# Altium schematic sheet Sheet1.SchDoc(Sheet1)
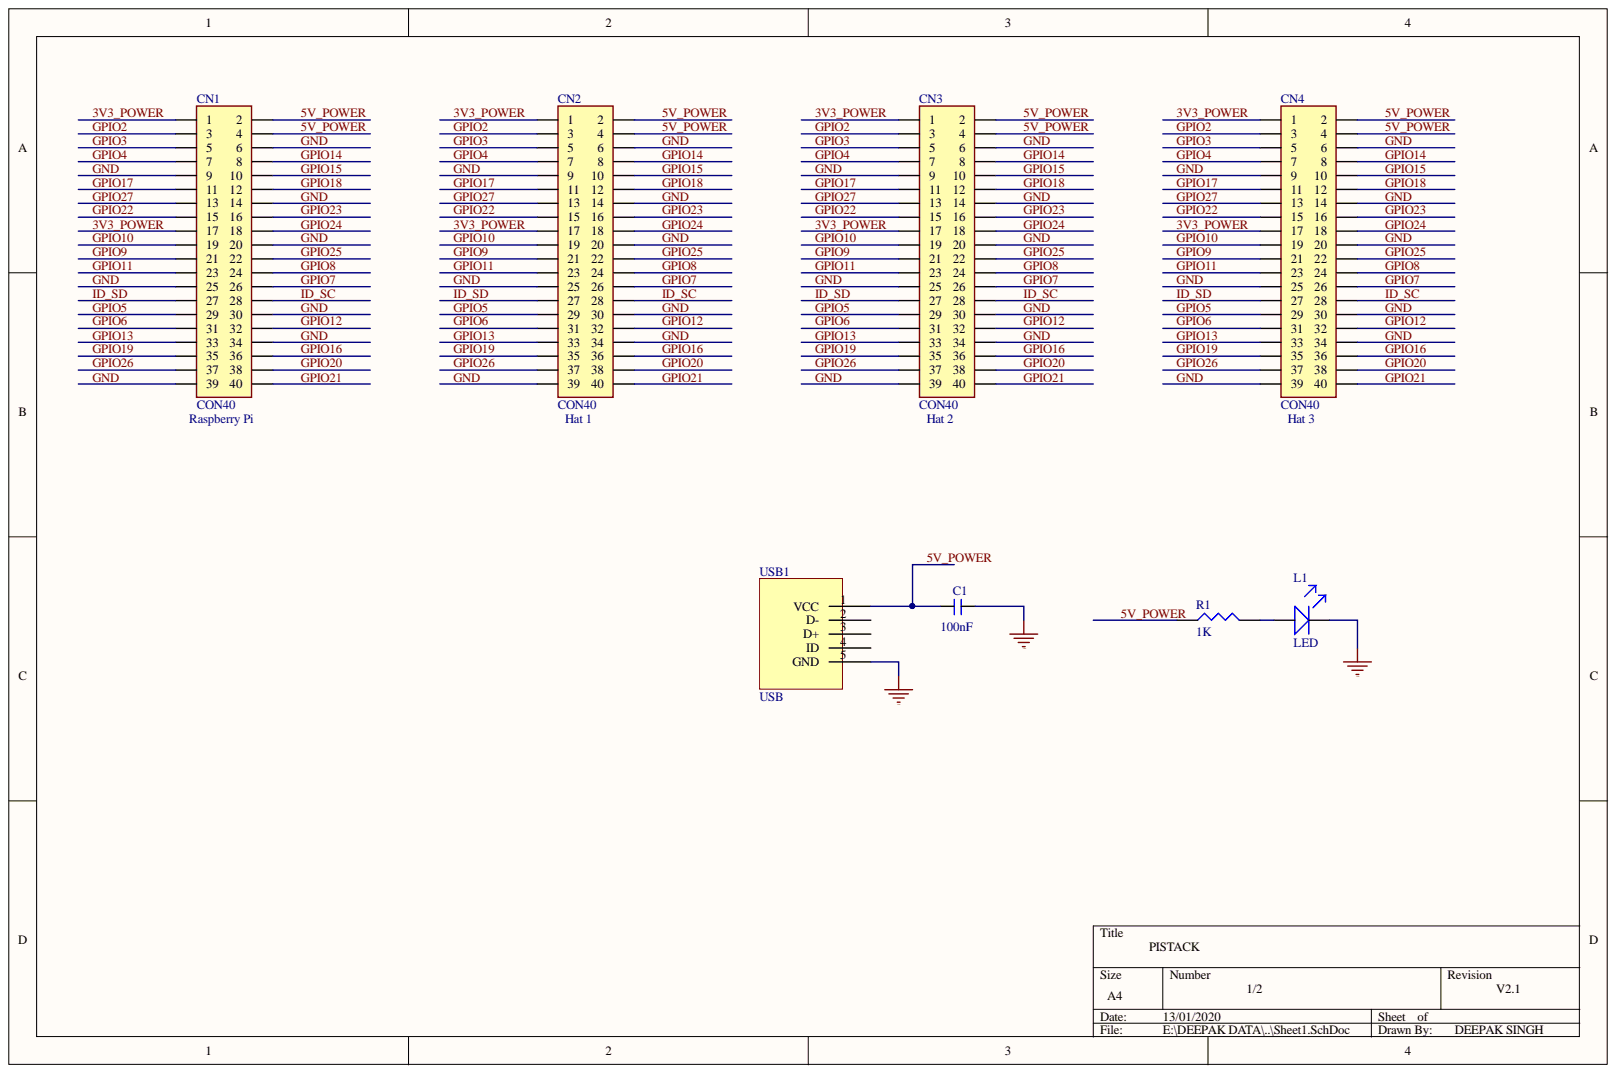
[diagram p0: Sheet1.SchDoc]

## Parts
C1  100nF  [0805]
CN1  CON40  [CON40_DD_2p5mm_TOP]
CN2  CON40  [CON40_DD_2p5mm_TOP]
CN3  CON40  [CON40_DD_2p5mm_TOP]
CN4  CON40  [CON40_DD_2p5mm_TOP]
L1  LED  [LED_0603_neg]
R1  1K  [0603]
USB1  USB  [USB_MICRO]

## Nets
3V3_POWER: CN1.1 CN1.17 CN2.1 CN2.17 CN3.1 CN3.17 CN4.1 CN4.17
5V_POWER: C1.1 CN1.2 CN1.4 CN2.2 CN2.4 CN3.2 CN3.4 CN4.2 CN4.4 R1.1 USB1.1(VCC)
GND: C1.2 CN1.6 CN1.9 CN1.14 CN1.20 CN1.25 CN1.30 CN1.34 CN1.39 CN2.6 CN2.9 CN2.14 CN2.20 CN2.25 CN2.30 CN2.34 CN2.39 CN3.6 CN3.9 CN3.14 CN3.20 CN3.25 CN3.30 CN3.34 CN3.39 CN4.6 CN4.9 CN4.14 CN4.20 CN4.25 CN4.30 CN4.34 CN4.39 L1.K USB1.5(GND)
GPIO2: CN1.3 CN2.3 CN3.3 CN4.3
GPIO3: CN1.5 CN2.5 CN3.5 CN4.5
GPIO4: CN1.7 CN2.7 CN3.7 CN4.7
GPIO5: CN1.29 CN2.29 CN3.29 CN4.29
GPIO6: CN1.31 CN2.31 CN3.31 CN4.31
GPIO7: CN1.26 CN2.26 CN3.26 CN4.26
GPIO8: CN1.24 CN2.24 CN3.24 CN4.24
GPIO9: CN1.21 CN2.21 CN3.21 CN4.21
GPIO10: CN1.19 CN2.19 CN3.19 CN4.19
GPIO11: CN1.23 CN2.23 CN3.23 CN4.23
GPIO12: CN1.32 CN2.32 CN3.32 CN4.32
GPIO13: CN1.33 CN2.33 CN3.33 CN4.33
GPIO14: CN1.8 CN2.8 CN3.8 CN4.8
GPIO15: CN1.10 CN2.10 CN3.10 CN4.10
GPIO16: CN1.36 CN2.36 CN3.36 CN4.36
GPIO17: CN1.11 CN2.11 CN3.11 CN4.11
GPIO18: CN1.12 CN2.12 CN3.12 CN4.12
GPIO19: CN1.35 CN2.35 CN3.35 CN4.35
GPIO20: CN1.38 CN2.38 CN3.38 CN4.38
GPIO21: CN1.40 CN2.40 CN3.40 CN4.40
GPIO22: CN1.15 CN2.15 CN3.15 CN4.15
GPIO23: CN1.16 CN2.16 CN3.16 CN4.16
GPIO24: CN1.18 CN2.18 CN3.18 CN4.18
GPIO25: CN1.22 CN2.22 CN3.22 CN4.22
GPIO26: CN1.37 CN2.37 CN3.37 CN4.37
GPIO27: CN1.13 CN2.13 CN3.13 CN4.13
ID_SC: CN1.28 CN2.28 CN3.28 CN4.28
ID_SD: CN1.27 CN2.27 CN3.27 CN4.27
NetL1_A: L1.A R1.2

Not connected: USB1 2(D-) 3(D+) 4(ID)

## Library data of the parts
C1 = CAP_NONPOLAR: Component Kind: Standard; Library Name: dxp_pasl_lib.IntLib
R1 = RES: Component Kind: Standard; Library Name: dxp_pasl_lib.IntLib
USB1 = USB_MICRO: Component Kind: Standard; Library Name: dxp_pasl_lib.IntLib
CN1-CN4 = CON40: Component Kind: Standard; Library Name: dxp_pasl_lib.IntLib
L1 = LED: Component Kind: Standard; Library Name: dxp_pasl_lib.IntLib
